# Altium schematic sheet CapSenseTop.SchDoc
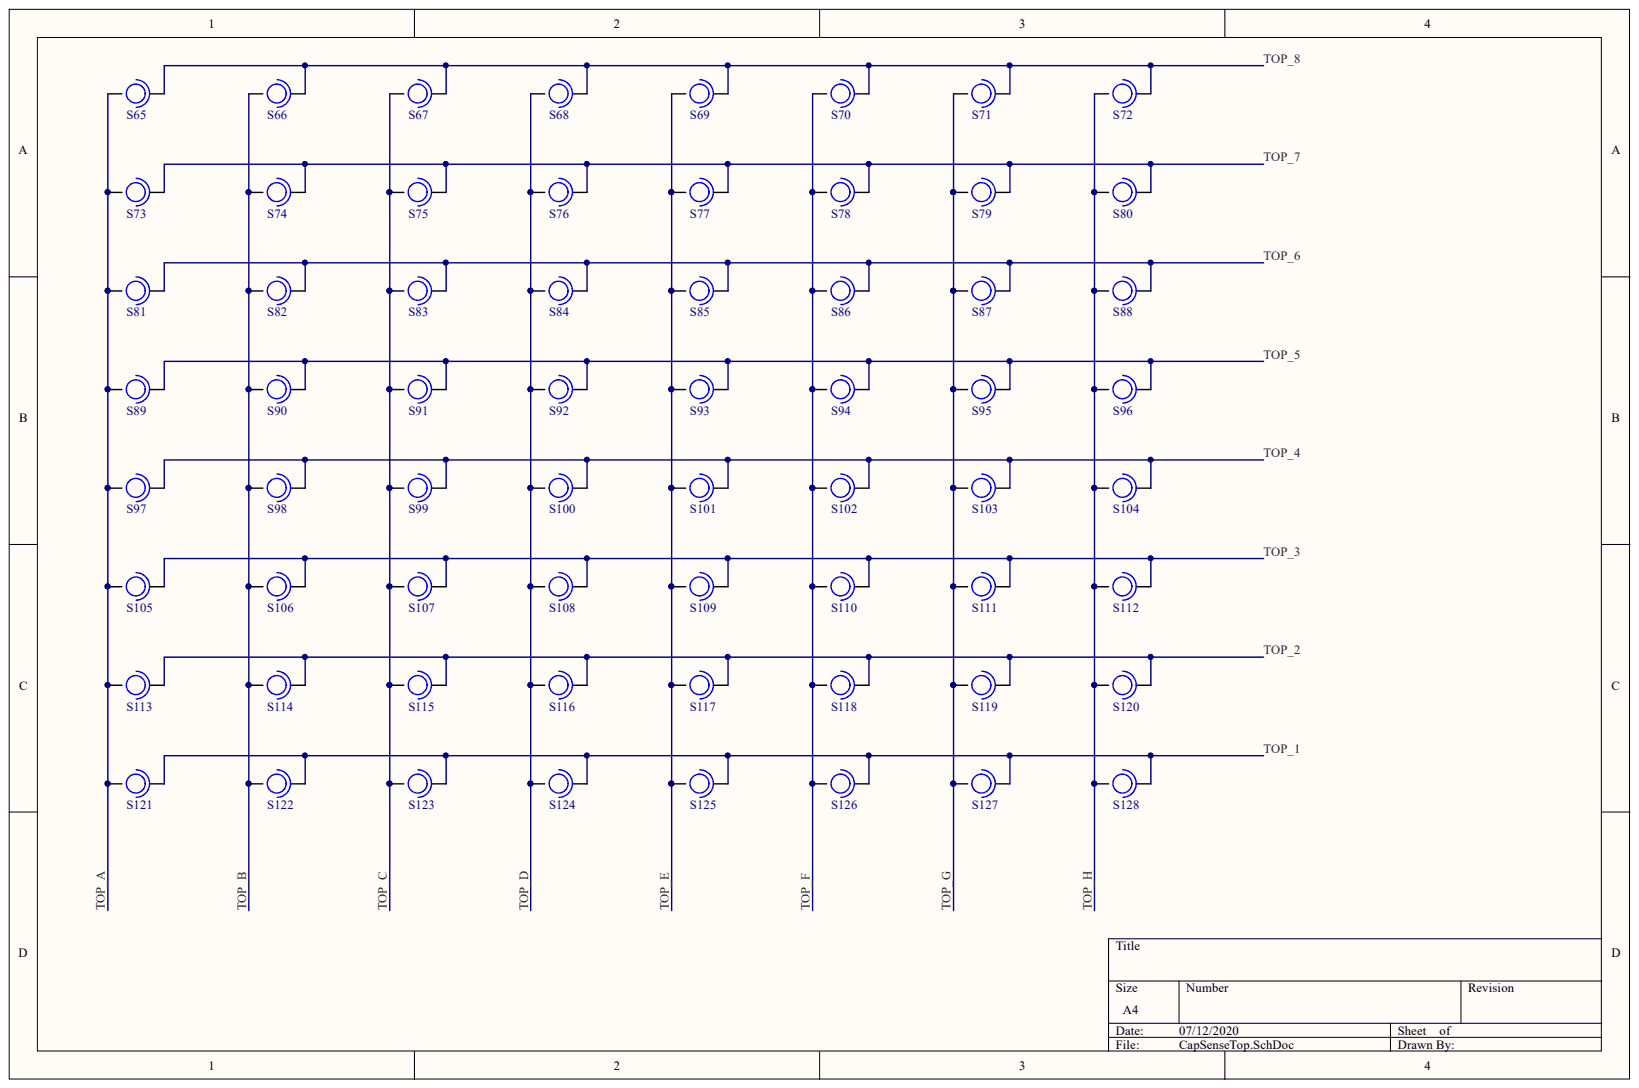
[diagram p0: CapSenseTop.SchDoc]

## Parts
S65  sense_cap_chess_20mm  [PCB-23fkddm5n7nwthazufj0-1]  (value not drawn)
S66  sense_cap_chess_20mm  [PCB-23fkddm5n7nwthazufj0-1]  (value not drawn)
S67  sense_cap_chess_20mm  [PCB-23fkddm5n7nwthazufj0-1]  (value not drawn)
S68  sense_cap_chess_20mm  [PCB-23fkddm5n7nwthazufj0-1]  (value not drawn)
S69  sense_cap_chess_20mm  [PCB-23fkddm5n7nwthazufj0-1]  (value not drawn)
S70  sense_cap_chess_20mm  [PCB-23fkddm5n7nwthazufj0-1]  (value not drawn)
S71  sense_cap_chess_20mm  [PCB-23fkddm5n7nwthazufj0-1]  (value not drawn)
S72  sense_cap_chess_20mm  [PCB-23fkddm5n7nwthazufj0-1]  (value not drawn)
S73  sense_cap_chess_20mm  [PCB-23fkddm5n7nwthazufj0-1]  (value not drawn)
S74  sense_cap_chess_20mm  [PCB-23fkddm5n7nwthazufj0-1]  (value not drawn)
S75  sense_cap_chess_20mm  [PCB-23fkddm5n7nwthazufj0-1]  (value not drawn)
S76  sense_cap_chess_20mm  [PCB-23fkddm5n7nwthazufj0-1]  (value not drawn)
S77  sense_cap_chess_20mm  [PCB-23fkddm5n7nwthazufj0-1]  (value not drawn)
S78  sense_cap_chess_20mm  [PCB-23fkddm5n7nwthazufj0-1]  (value not drawn)
S79  sense_cap_chess_20mm  [PCB-23fkddm5n7nwthazufj0-1]  (value not drawn)
S80  sense_cap_chess_20mm  [PCB-23fkddm5n7nwthazufj0-1]  (value not drawn)
S81  sense_cap_chess_20mm  [PCB-23fkddm5n7nwthazufj0-1]  (value not drawn)
S82  sense_cap_chess_20mm  [PCB-23fkddm5n7nwthazufj0-1]  (value not drawn)
S83  sense_cap_chess_20mm  [PCB-23fkddm5n7nwthazufj0-1]  (value not drawn)
S84  sense_cap_chess_20mm  [PCB-23fkddm5n7nwthazufj0-1]  (value not drawn)
S85  sense_cap_chess_20mm  [PCB-23fkddm5n7nwthazufj0-1]  (value not drawn)
S86  sense_cap_chess_20mm  [PCB-23fkddm5n7nwthazufj0-1]  (value not drawn)
S87  sense_cap_chess_20mm  [PCB-23fkddm5n7nwthazufj0-1]  (value not drawn)
S88  sense_cap_chess_20mm  [PCB-23fkddm5n7nwthazufj0-1]  (value not drawn)
S89  sense_cap_chess_20mm  [PCB-23fkddm5n7nwthazufj0-1]  (value not drawn)
S90  sense_cap_chess_20mm  [PCB-23fkddm5n7nwthazufj0-1]  (value not drawn)
S91  sense_cap_chess_20mm  [PCB-23fkddm5n7nwthazufj0-1]  (value not drawn)
S92  sense_cap_chess_20mm  [PCB-23fkddm5n7nwthazufj0-1]  (value not drawn)
S93  sense_cap_chess_20mm  [PCB-23fkddm5n7nwthazufj0-1]  (value not drawn)
S94  sense_cap_chess_20mm  [PCB-23fkddm5n7nwthazufj0-1]  (value not drawn)
S95  sense_cap_chess_20mm  [PCB-23fkddm5n7nwthazufj0-1]  (value not drawn)
S96  sense_cap_chess_20mm  [PCB-23fkddm5n7nwthazufj0-1]  (value not drawn)
S97  sense_cap_chess_20mm  [PCB-23fkddm5n7nwthazufj0-1]  (value not drawn)
S98  sense_cap_chess_20mm  [PCB-23fkddm5n7nwthazufj0-1]  (value not drawn)
S99  sense_cap_chess_20mm  [PCB-23fkddm5n7nwthazufj0-1]  (value not drawn)
S100  sense_cap_chess_20mm  [PCB-23fkddm5n7nwthazufj0-1]  (value not drawn)
S101  sense_cap_chess_20mm  [PCB-23fkddm5n7nwthazufj0-1]  (value not drawn)
S102  sense_cap_chess_20mm  [PCB-23fkddm5n7nwthazufj0-1]  (value not drawn)
S103  sense_cap_chess_20mm  [PCB-23fkddm5n7nwthazufj0-1]  (value not drawn)
S104  sense_cap_chess_20mm  [PCB-23fkddm5n7nwthazufj0-1]  (value not drawn)
S105  sense_cap_chess_20mm  [PCB-23fkddm5n7nwthazufj0-1]  (value not drawn)
S106  sense_cap_chess_20mm  [PCB-23fkddm5n7nwthazufj0-1]  (value not drawn)
S107  sense_cap_chess_20mm  [PCB-23fkddm5n7nwthazufj0-1]  (value not drawn)
S108  sense_cap_chess_20mm  [PCB-23fkddm5n7nwthazufj0-1]  (value not drawn)
S109  sense_cap_chess_20mm  [PCB-23fkddm5n7nwthazufj0-1]  (value not drawn)
S110  sense_cap_chess_20mm  [PCB-23fkddm5n7nwthazufj0-1]  (value not drawn)
S111  sense_cap_chess_20mm  [PCB-23fkddm5n7nwthazufj0-1]  (value not drawn)
S112  sense_cap_chess_20mm  [PCB-23fkddm5n7nwthazufj0-1]  (value not drawn)
S113  sense_cap_chess_20mm  [PCB-23fkddm5n7nwthazufj0-1]  (value not drawn)
S114  sense_cap_chess_20mm  [PCB-23fkddm5n7nwthazufj0-1]  (value not drawn)
S115  sense_cap_chess_20mm  [PCB-23fkddm5n7nwthazufj0-1]  (value not drawn)
S116  sense_cap_chess_20mm  [PCB-23fkddm5n7nwthazufj0-1]  (value not drawn)
S117  sense_cap_chess_20mm  [PCB-23fkddm5n7nwthazufj0-1]  (value not drawn)
S118  sense_cap_chess_20mm  [PCB-23fkddm5n7nwthazufj0-1]  (value not drawn)
S119  sense_cap_chess_20mm  [PCB-23fkddm5n7nwthazufj0-1]  (value not drawn)
S120  sense_cap_chess_20mm  [PCB-23fkddm5n7nwthazufj0-1]  (value not drawn)
S121  sense_cap_chess_20mm  [PCB-23fkddm5n7nwthazufj0-1]  (value not drawn)
S122  sense_cap_chess_20mm  [PCB-23fkddm5n7nwthazufj0-1]  (value not drawn)
S123  sense_cap_chess_20mm  [PCB-23fkddm5n7nwthazufj0-1]  (value not drawn)
S124  sense_cap_chess_20mm  [PCB-23fkddm5n7nwthazufj0-1]  (value not drawn)
S125  sense_cap_chess_20mm  [PCB-23fkddm5n7nwthazufj0-1]  (value not drawn)
S126  sense_cap_chess_20mm  [PCB-23fkddm5n7nwthazufj0-1]  (value not drawn)
S127  sense_cap_chess_20mm  [PCB-23fkddm5n7nwthazufj0-1]  (value not drawn)
S128  sense_cap_chess_20mm  [PCB-23fkddm5n7nwthazufj0-1]  (value not drawn)

## Nets
TOP_1: S121.2 S122.2 S123.2 S124.2 S125.2 S126.2 S127.2 S128.2
TOP_2: S113.2 S114.2 S115.2 S116.2 S117.2 S118.2 S119.2 S120.2
TOP_3: S105.2 S106.2 S107.2 S108.2 S109.2 S110.2 S111.2 S112.2
TOP_4: S97.2 S98.2 S99.2 S100.2 S101.2 S102.2 S103.2 S104.2
TOP_5: S89.2 S90.2 S91.2 S92.2 S93.2 S94.2 S95.2 S96.2
TOP_6: S81.2 S82.2 S83.2 S84.2 S85.2 S86.2 S87.2 S88.2
TOP_7: S73.2 S74.2 S75.2 S76.2 S77.2 S78.2 S79.2 S80.2
TOP_8: S65.2 S66.2 S67.2 S68.2 S69.2 S70.2 S71.2 S72.2
TOP_A: S65.1 S73.1 S81.1 S89.1 S97.1 S105.1 S113.1 S121.1
TOP_B: S66.1 S74.1 S82.1 S90.1 S98.1 S106.1 S114.1 S122.1
TOP_C: S67.1 S75.1 S83.1 S91.1 S99.1 S107.1 S115.1 S123.1
TOP_D: S68.1 S76.1 S84.1 S92.1 S100.1 S108.1 S116.1 S124.1
TOP_E: S69.1 S77.1 S85.1 S93.1 S101.1 S109.1 S117.1 S125.1
TOP_F: S70.1 S78.1 S86.1 S94.1 S102.1 S110.1 S118.1 S126.1
TOP_G: S71.1 S79.1 S87.1 S95.1 S103.1 S111.1 S119.1 S127.1
TOP_H: S72.1 S80.1 S88.1 S96.1 S104.1 S112.1 S120.1 S128.1

## Library data of the parts
S65-S128 = CMP-q6kamju61frmo791n94x-4: 20x20mm sensing footprint; Component Kind: Standard; Library Name: CommunityVault
